# Altium schematic sheet [08] - ANALOG AND SERVO.SchDoc("[08] - ANALOG AND SERVO")
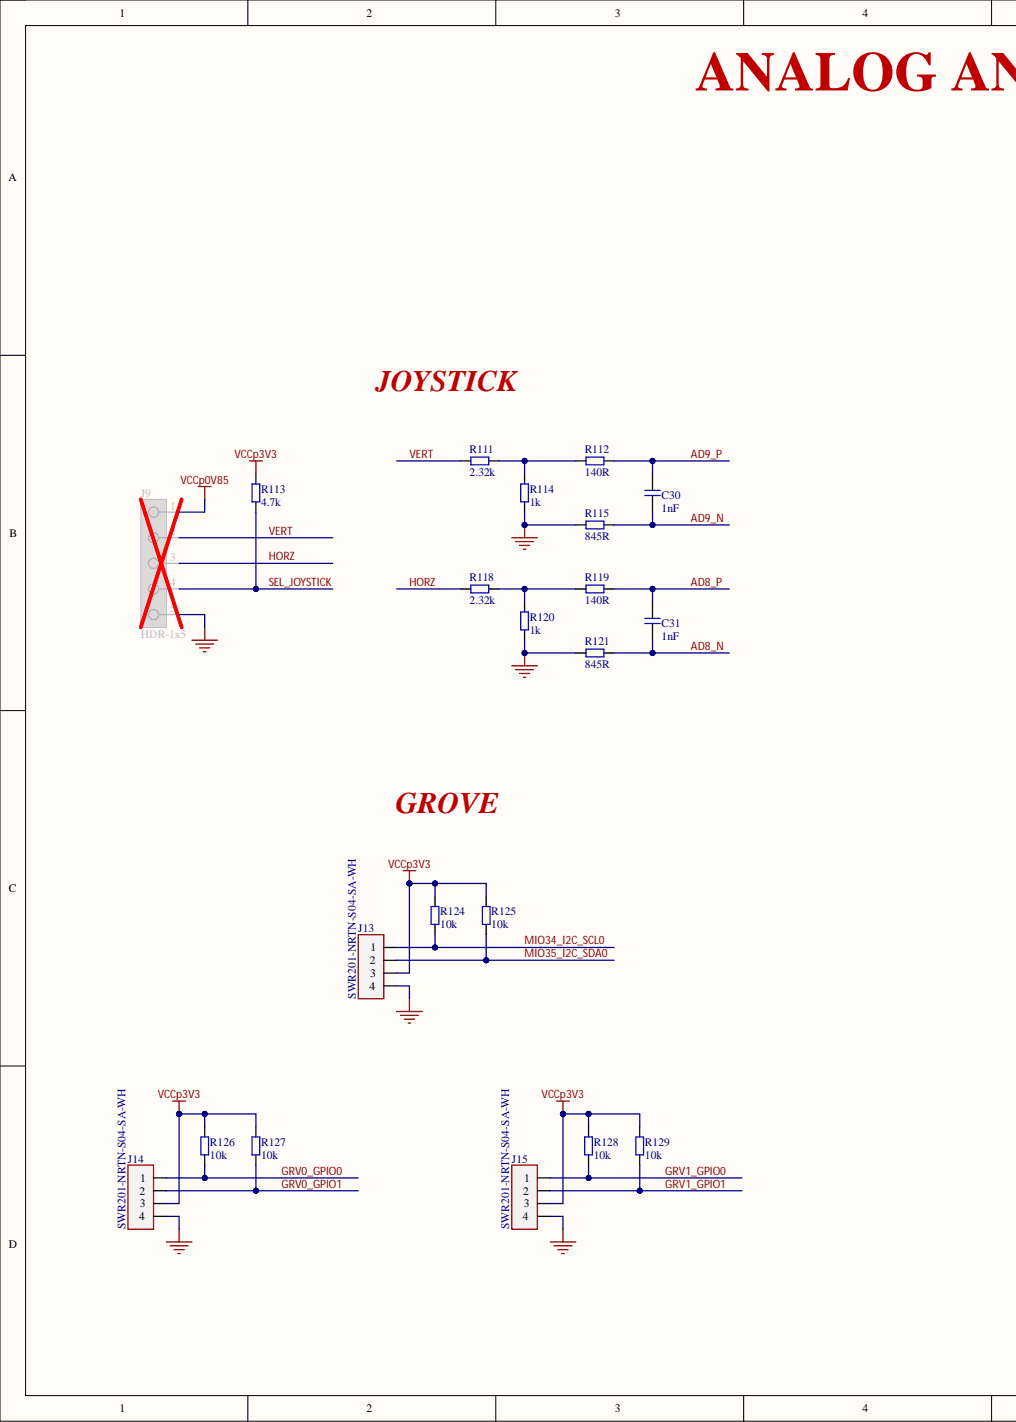
[diagram p0: [08] - ANALOG AND SERVO.SchDoc, left]
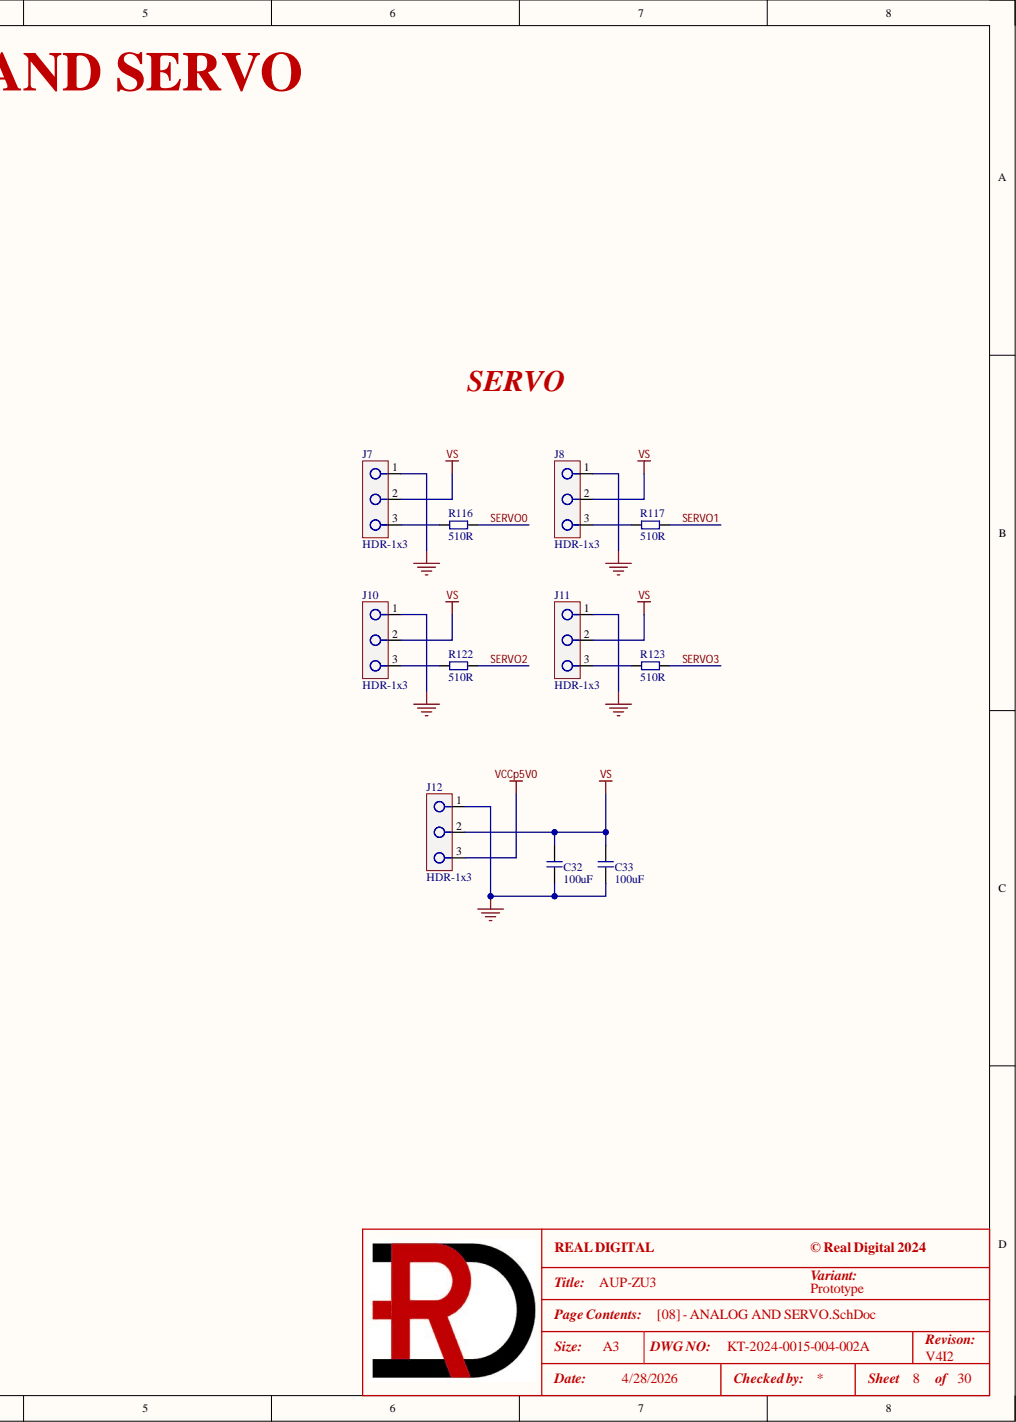
[diagram p1: [08] - ANALOG AND SERVO.SchDoc, right]

## Parts
C30  1nF  [C0402]
C31  1nF  [C0402]
C32  100uF  [C1210]
C33  100uF  [C1210]
J7  HDR-1x3  [HDR1X3]
J8  HDR-1x3  [HDR1X3]
J9  HDR-1x5  [HDR1X5 - OFF]
J10  HDR-1x3  [HDR1X3]
J11  HDR-1x3  [HDR1X3]
J12  HDR-1x3  [HDR1X3]
J13  SWR201-NRTN-S04-SA-WH  [SWR201-NRTN-S04-SA-WH]
J14  SWR201-NRTN-S04-SA-WH  [SWR201-NRTN-S04-SA-WH]
J15  SWR201-NRTN-S04-SA-WH  [SWR201-NRTN-S04-SA-WH]
R111  2.32k  [R0402]
R112  140R  [R0402]
R113  4.7k  [R0402]
R114  1k  [R0402]
R115  845R  [R0402]
R116  510R  [R0402]
R117  510R  [R0402]
R118  2.32k  [R0402]
R119  140R  [R0402]
R120  1k  [R0402]
R121  845R  [R0402]
R122  510R  [R0402]
R123  510R  [R0402]
R124  10k  [R0402]
R125  10k  [R0402]
R126  10k  [R0402]
R127  10k  [R0402]
R128  10k  [R0402]
R129  10k  [R0402]

## Nets
GND: C32.1 C33.1 J7.1 J8.1 J9.5 J10.1 J11.1 J12.1 J13.4 J14.4 J15.4 R114.1 R115.1 R120.1 R121.1
VCCp0V85: J9.1
VCCp3V3: J13.3 J14.3 J15.3 R113.2 R124.2 R125.2 R126.2 R127.2 R128.2 R129.2
VCCp5V0: J12.3
VS: C32.2 C33.2 J7.2 J8.2 J10.2 J11.2 J12.2
AD8_N: C31.1 R121.2
AD8_P: C31.2 R119.2
AD9_N: C30.1 R115.2
AD9_P: C30.2 R112.2
GRV0_GPIO0: J14.1 R126.1
GRV0_GPIO1: J14.2 R127.1
GRV1_GPIO0: J15.1 R128.1
GRV1_GPIO1: J15.2 R129.1
HORZ: J9.3 R118.2
MIO34_I2C_SCL0: J13.1 R124.1
MIO35_I2C_SDA0: J13.2 R125.1
SEL_JOYSTICK: J9.4 R113.1
SERVO0: R116.2
SERVO1: R117.2
SERVO2: R122.2
SERVO3: R123.2
VERT: J9.2 R111.2
NetJ7_3: J7.3 R116.1
NetJ8_3: J8.3 R117.1
NetJ10_3: J10.3 R122.1
NetJ11_3: J11.3 R123.1
NetR111_1: R111.1 R112.1 R114.2
NetR118_1: R118.1 R119.1 R120.2

## Library data of the parts
C30 C31 = GRM1555C1H102JA01D: CAP CER 1000pF 50V C0G/NP0 0402; Manufacturer 1: Murata Electronics; .Checked_PCB: RV; C P/N: RD-111-0048; LoadStatus: Load; Temperature Coefficient: C0G, NP0; Tolerance: 5%; Category: Capacitors; Component Kind: Standard; Library Name: KT-RealDigital.IntLib; Manufacturer 1 Part Number: GRM1555C1H102JA01D; Voltage - Rated: 50V; .Checked_SCH: RV; .Creator: RV
C32 C33 = GRM32ER61A107ME20L: CAP CER 100uF 10V X5R 1210; Manufacturer 1: Murata Electronics; .Checked_PCB: AC; .Creator: RV; C P/N: RD-111-0004; LoadStatus: Load; Tolerance: 20%; Category: Capacitors; Component Kind: Standard; Library Name: KT-RealDigital.IntLib; Manufacturer 1 Part Number: GRM32ER61A107ME20L; Temperature Coefficient: X5R; Voltage - Rated: 10V; .Checked_SCH: AC
J7 J8 J10-J12 = HDR-1x3: Header 2.54mm, 1x3; Manufacturer 1: Centronic Precision Electronic; Manufacturer 1 Part Number: H6-80-03; .Checked_PCB: AC; .Creator: RV; C P/N: 163-0035; LoadStatus: Load; Category: Header; Component Kind: Standard; Library Name: KT-RealDigital.IntLib; .Checked_SCH: AC
J9 = HDR-1x5: Header 2.54mm, 1x5; Manufacturer 1: Centronic Precision Electronic; Manufacturer 1 Part Number: H6-80-05; .Checked_PCB: AC; .Creator: RV; C P/N: 163-0067; LoadStatus: Load; Category: Header; Component Kind: Standard; Library Name: KT-RealDigital.IntLib; .Checked_SCH: AC
J13-J15 = SWR201-NRTN-S04-SA-WH: CONN HEADER VERT 4POS 2.54MM; Manufacturer 1: Sullins Connector Solutions; .Checked_PCB: RV; .Creator: DG; C P/N: RD-163-0067; LoadStatus: Load; Category: Header; Component Kind: Standard; Library Name: KT-RealDigital.IntLib; Manufacturer 1 Part Number: SWR201-NRTN-S04-SA-WH; .Checked_SCH: RV
R111 R118 = RC0402FR-072K32L: RES SMD 2.32K OHM 1% 1/16W 0402; Manufacturer 1: YAGEO; .Checked_PCB: RV; C P/N: 101-0423; LoadStatus: Load; Tolerance: 1%/TK100; Category: Resistors; Component Kind: Standard; Library Name: KT-RealDigital.IntLib; Manufacturer 1 Part Number: RC0402FR-072K32L; .Checked_SCH: RV; .Creator: RV
R112 R119 = RC0402FR-07140RL: RES SMD 140 OHM 1% 1/16W 0402; Manufacturer 1: Yageo; .Checked_PCB: RV; C P/N: 101-0343; LoadStatus: Load; Tolerance: 1%/TK100; Category: Resistors; Component Kind: Standard; Library Name: KT-RealDigital.IntLib; Manufacturer 1 Part Number: RC0402FR-07140RL; .Checked_SCH: RV; .Creator: RV
R113 = RC0402FR-074K7P: RES SMD 4.7K OHM 1% 1/16W 0402; Manufacturer 1: Yageo; .Checked_PCB: AC; .Creator: RV; C P/N: 101-0129; LoadStatus: Load; Tolerance: 1%/TK100; Category: Resistors; Component Kind: Standard; Library Name: KT-RealDigital.IntLib; Manufacturer 1 Part Number: RC0402FR-074K7P; .Checked_SCH: AC
R114 R120 = RC0402FR-071KL: RES SMD 1K OHM 1% 1/16W 0402; Manufacturer 1: Yageo; .Checked_PCB: RV; C P/N: RD-101-0010; LoadStatus: Load; Tolerance: 1%/TK100; Category: Resistors; Component Kind: Standard; Library Name: KT-RealDigital.IntLib; Manufacturer 1 Part Number: RC0402FR-071KL; .Checked_SCH: RV; .Creator: RV
R115 R121 = RC0402FR-07845RL: RES SMD 845 OHM 1% 1/16W 0402; Manufacturer 1: Yageo; .Checked_PCB: RV; C P/N: RD-101-0071; LoadStatus: Load; Tolerance: 1%/TK100; Category: Resistors; Component Kind: Standard; Library Name: KT-RealDigital.IntLib; Manufacturer 1 Part Number: RC0402FR-07845RL; .Checked_SCH: RV; .Creator: RV
R116 R117 R122 R123 = RC0402JR-0751RL: RES SMD 51 OHM 5% 1/16W 0402; Manufacturer 1: Yageo; .Checked_PCB: AC; .Creator: RV; C P/N: 101-0040; LoadStatus: Load; Tolerance: 5%/TK100; Category: Resistors; Component Kind: Standard; Library Name: KT-RealDigital.IntLib; Manufacturer 1 Part Number: RC0402JR-0751RL; .Checked_SCH: AC
R124-R129 = RC0402FR-0710KL: RES SMD 10K OHM 1% 1/16W 0402; Manufacturer 1: Yageo; .Checked_PCB: AC; .Creator: RV; C P/N: RD-101-0020; LoadStatus: Load; Tolerance: 1%/TK100; Category: Resistors; Component Kind: Standard; Library Name: KT-RealDigital.IntLib; Manufacturer 1 Part Number: RC0402FR-0710KL; .Checked_SCH: AC
